# Altium schematic sheet PARK_COUNTER_PT1_2.SchDoc(PARK_COUNTER_PT1_2)
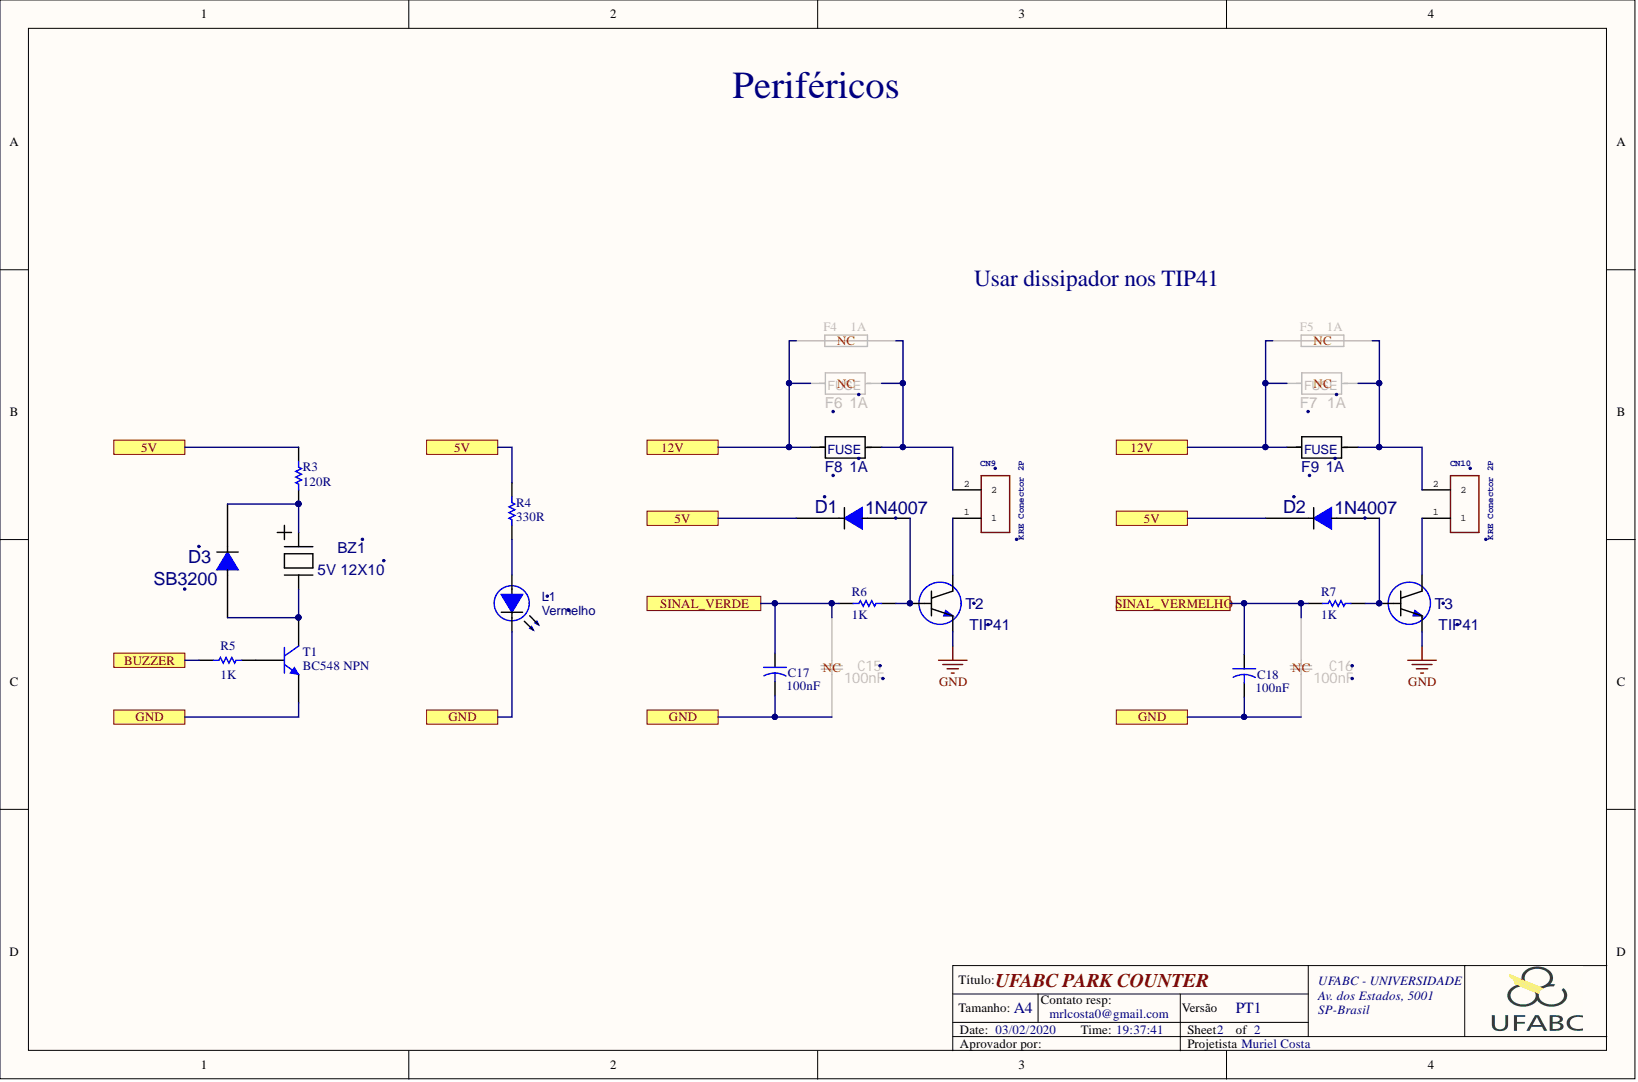
[diagram p0: PARK_COUNTER_PT1_2.SchDoc]

## Parts
BZ1  5V 12X10  [BUZZER 12mm]
C15  value 100nF  [C0603]  (hidden comment: C0603)
C16  value 100nF  [C0603]  (hidden comment: C0603)
C17  value 100nF  [CAPR5-4X5]  (hidden comment: Cap2)
C18  value 100nF  [CAPR5-4X5]  (hidden comment: Cap2)
CN9  KRE Conector 2P  [KRE-2P]
CN10  KRE Conector 2P  [KRE-2P]
D1  value 1N4007  [DO-35_1]  (hidden comment: 1N400X)
D2  value 1N4007  [DO-35_1]  (hidden comment: 1N400X)
D3  value SB3200  [DO-35_1]  (hidden comment: 1N400X)
F4  1A  [PIN-W2/E2.8]
F5  1A  [PIN-W2/E2.8]
F6  value 1A  [MINI_FUSE_BOARD_GLASS]  (hidden comment: MINI_FUSE_BOARD_GLASS)
F7  value 1A  [MINI_FUSE_BOARD_GLASS]  (hidden comment: MINI_FUSE_BOARD_GLASS)
F8  value 1A  [fuse_board_suporte]  (hidden comment: MINI_FUSE_BOARD_SUP_GLASS)
F9  value 1A  [fuse_board_suporte]  (hidden comment: MINI_FUSE_BOARD_SUP_GLASS)
L1  value Vermelho  [LED5MM_180G]  (hidden comment: LED5MM_180G)
R3  value 120R  [AXIAL-0.3]  (hidden comment: Res1)
R4  value 330R  [AXIAL-0.3]  (hidden comment: Res1)
R5  value 1K  [AXIAL-0.3]  (hidden comment: Res1)
R6  value 1K  [AXIAL-0.3]  (hidden comment: Res1)
R7  value 1K  [AXIAL-0.3]  (hidden comment: Res1)
T1  BC548 NPN  [TO-226-AA]
T2  TIP 41  value TIP41  [TO-220]
T3  TIP 41  value TIP41  [TO-220]

## Ports
5V, 12V, BUZZER, GND, SINAL_VERDE, SINAL_VERMELHO

## Nets
GND: T2.3 T3.3
5V: D1.1 D2.1 R3.2 R4.1 ; port 5V
12V: F4.1 F5.1 F6.2 F7.2 F8.2 F9.2 ; port 12V
BUZZER: R5.1 ; port BUZZER
SINAL_VERDE: C15.2 C17.1 R6.1 ; port SINAL_VERDE
SINAL_VERMELHO: C16.2 C18.1 R7.1 ; port SINAL_VERMELHO
NetBZ1_1: BZ1.1 D3.1 R3.1
NetBZ1_2: BZ1.2 D3.2 T1.1
NetC15_1: C15.1 C16.1 C17.2 C18.2 L1.2 T1.3 ; port GND
NetCN9_1: CN9.1 T2.2
NetCN9_2: CN9.2 F4.2 F6.1 F8.1
NetCN10_1: CN10.1 T3.2
NetCN10_2: CN10.2 F5.2 F7.1 F9.1
NetD1_2: D1.2 R6.2 T2.1
NetD2_2: D2.2 R7.2 T3.1
NetL1_1: L1.1 R4.2
NetR5_2: R5.2 T1.2

## Library data of the parts
BZ1 = BZ_MINI_6V_S/OSC_D12_A10_P7,5: BUZZER d12mm h10mm p7,5mm; Part Number: 009.01.00011; Value: 5V 12X10; Component Kind: Standard; Library Name: PTH.SchLib
C15 C16 = C0603: Capacitor; Library Name: SMD.SchLib; Component Kind: Standard
C17 C18 = Cap2: Capacitor; Simulation: CAP; Signal Integrity: Cap; Published: 23-Sep-2002; PackageReference: CAPR5-4X5; PackageDescription: Capacitor; 2 Leads; Library Name: Miscellaneous Devices.IntLib; Component Kind: Standard; Publisher: Altium Limited
CN9 CN10 = KRE-2P: GateName_1: G$1; SymbolName_1: KRE-2P; Package: KRE-2P; LibraryName: kre; Library Name: kre.IntLib; DeviceSetName: KRE-2P; Component Kind: Standard
D1-D3 = 1N400X: Diode; Component Kind: Standard
  D1 D2: Library Name: PTH.IntLib
  D3: Library Name: PTH.SchLib
F4 F5 = Fuse 1: Fuse; Simulation: FUSE; Published: 8-Jun-2000; PackageReference: PIN-W2/E2.8; PackageDescription: Thru-Hole; 2 Leads; Library Name: Miscellaneous Devices.IntLib; LatestRevisionNote: Re-released for DXP Platform.; LatestRevisionDate: 17-Jul-2002; Component Kind: Standard; Publisher: Altium Limited
F6 F7 = fuse_board_glass: Component Kind: Standard; Library Name: PTH.SchLib
F8 F9 = fuse_board_suporte: Component Kind: Standard; Library Name: PTH.SchLib
L1 = LED5MM_180G: Light Emitting Diode; Component Kind: Standard; Library Name: PTH.IntLib
R3-R7 = Res1: Resistor; Simulation: RESISTOR; Signal Integrity: Res; Published: 8-Jun-2000; PackageReference: AXIAL-0.3; PackageDescription: Axial Device, Thru-Hole; 2 Leads; 0.3 in Pin Spacing; Library Name: Miscellaneous Devices.IntLib; LatestRevisionNote: Re-released for DXP Platform.; LatestRevisionDate: 17-Jul-2002; Component Kind: Standard; Publisher: Altium Limited
T1 = NPN: NPN Bipolar Transistor; Simulation: NPN; Signal Integrity: NPN; Published: 8-Jun-2000; PackageReference: TO-226-AA; PackageDescription: TO, Flat Index; 3 In-Line, Axial Leads; Body Dia. 4.8mm; Leads 0.55 x 0.51 mm (max); Library Name: Miscellaneous Devices.IntLib; LatestRevisionNote: Re-released for DXP Platform.; LatestRevisionDate: 17-Jul-2002; Component Kind: Standard; Code_JEDEC: TO-226-AA; Publisher: Altium Limited
T2 T3 = T_TIP41: NPN Transistor; Component Kind: Standard; Library Name: PTH.SchLib
